# Altium schematic sheet BMS_Main.SchDoc
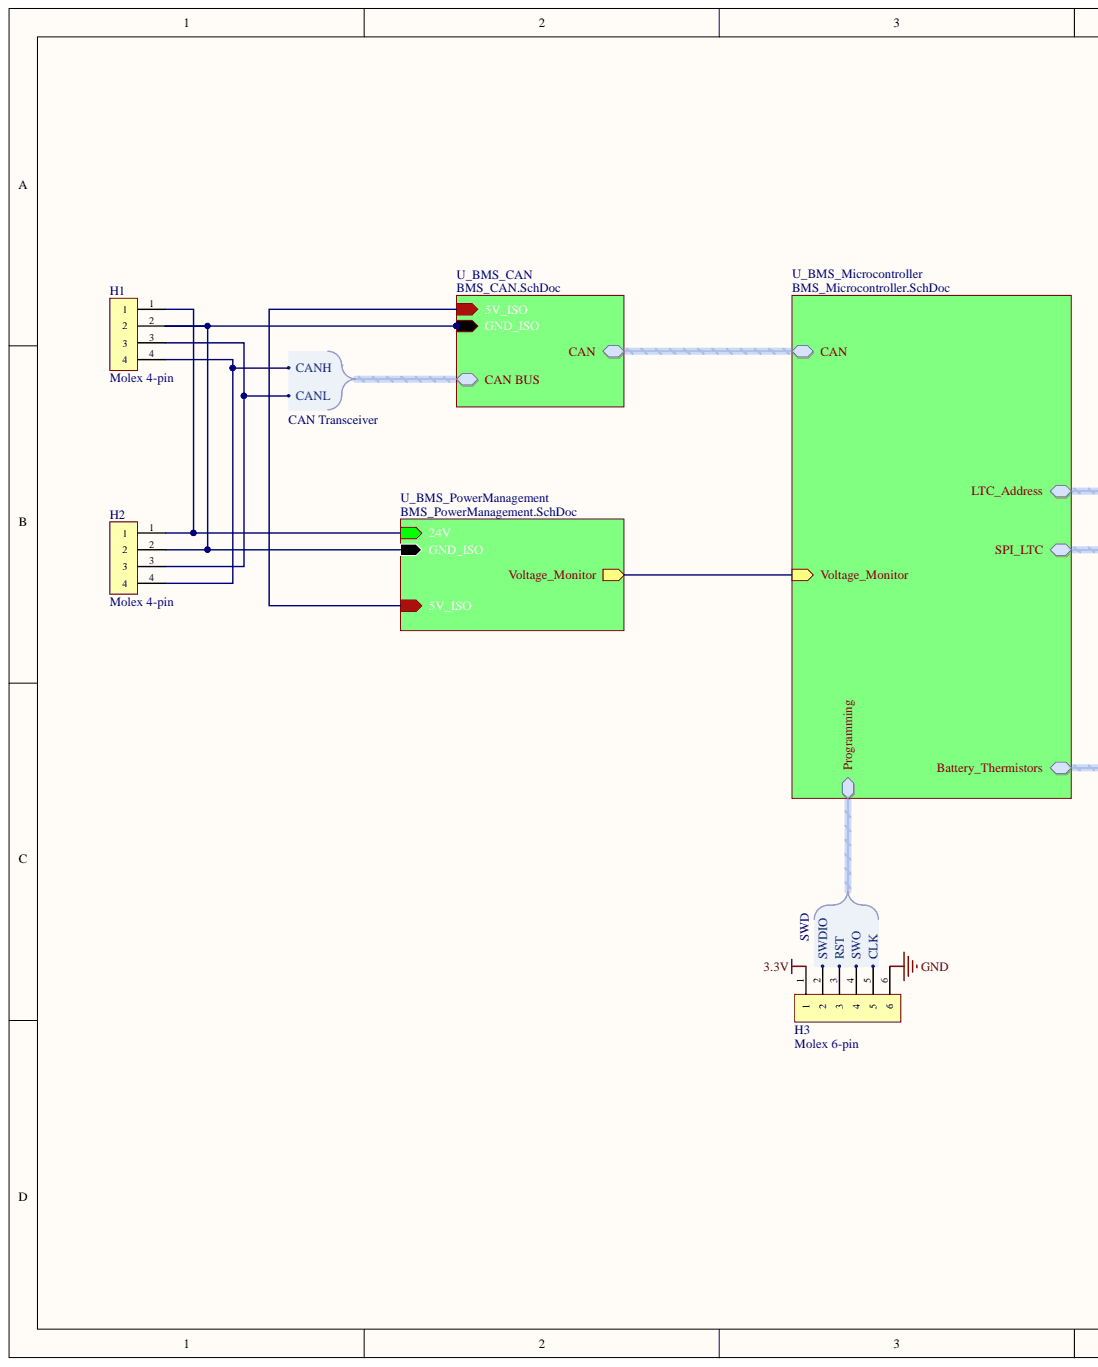
[diagram p0: BMS_Main.SchDoc, left]
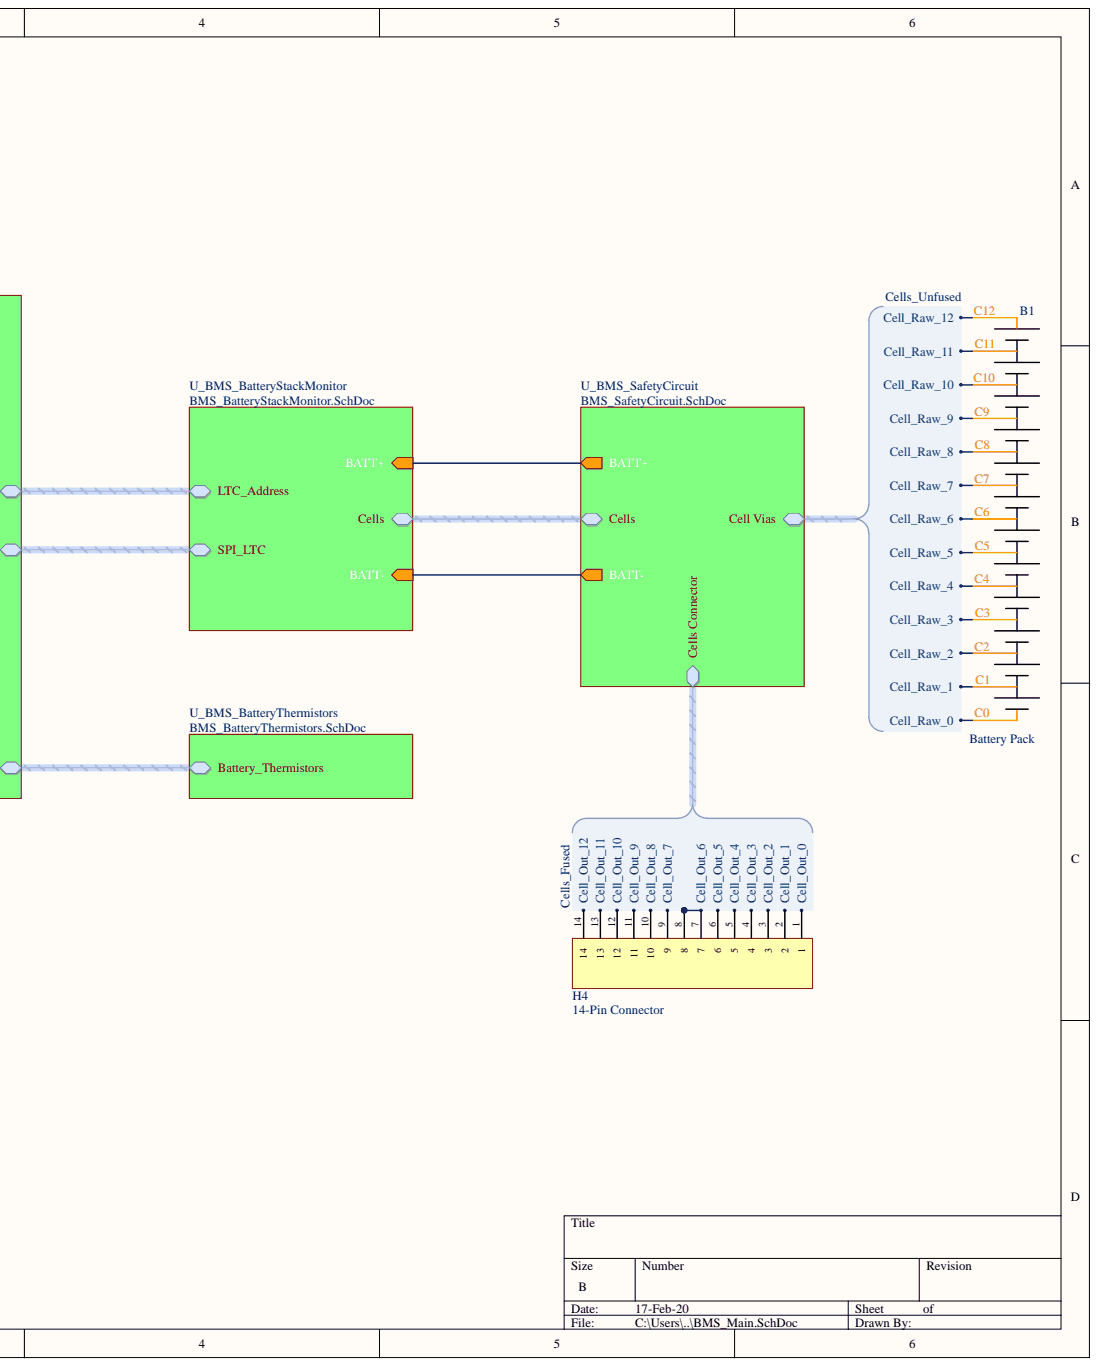
[diagram p1: BMS_Main.SchDoc, right]

## Parts
B1  Battery Pack  [Cells]
H1  Molex 4-pin  [Communication Connector]
H2  Molex 4-pin  [Communication Connector]
H3  Molex 6-pin  [ProgrammingConnector]
H4  14-Pin Connector  [CellConnector]

## Nets
3.3V: H3.1
GND: H3.6
NetB1_0: B1.0
NetB1_1: B1.1
NetB1_2: B1.2
NetB1_3: B1.3
NetB1_4: B1.4
NetB1_5: B1.5
NetB1_6: B1.6
NetB1_7: B1.7
NetB1_8: B1.8
NetB1_9: B1.9
NetB1_10: B1.10
NetB1_11: B1.11
NetB1_12: B1.12
NetH1_1: H1.1 H2.1
NetH1_2: H1.2 H2.2
NetH1_3: H1.3 H2.3
NetH1_4: H1.4 H2.4
NetH3_2: H3.2
NetH3_3: H3.3
NetH3_4: H3.4
NetH3_5: H3.5
NetH4_1: H4.1
NetH4_2: H4.2
NetH4_3: H4.3
NetH4_4: H4.4
NetH4_5: H4.5
NetH4_6: H4.6
NetH4_7: H4.7 H4.8
NetH4_9: H4.9
NetH4_10: H4.10
NetH4_11: H4.11
NetH4_12: H4.12
NetH4_13: H4.13
NetH4_14: H4.14
5V_ISO:
BATT+:
BATT-:
Battery_Thermistors.Therm1:
Battery_Thermistors.Therm2:
Battery_Thermistors.Therm3:
Battery_Thermistors.Therm4:
Battery_Thermistors.Therm5:
CAN.RXD:
CAN.TXD:
Cells.Cell_0:
Cells.Cell_1:
Cells.Cell_2:
Cells.Cell_3:
Cells.Cell_4:
Cells.Cell_5:
Cells.Cell_6:
Cells.Cell_7:
Cells.Cell_8:
Cells.Cell_9:
Cells.Cell_10:
Cells.Cell_11:
Cells.Cell_12:
LTC_Address.A0:
LTC_Address.A1:
LTC_Address.A2:
LTC_Address.A3:
SPI_LTC.CS:
SPI_LTC.MISO:
SPI_LTC.MOSI:
SPI_LTC.SCK:
Voltage_Monitor:

## Library data of the parts
B1 = Battery Pack: 12s3p battery pack, LiFePO4 GH Battery Cell with 3.7V and 8Ah; Component Kind: Standard; Library Name: BatteryPack.SchLib
H1 H2 = Molex 4-pin: Micro-Fit 3.0 Vertical Header, 3.00mm Pitch, Dual Row, 4 Circuits, with Solder Tab, Tin, Glow-Wire Capable, Black + Micro-Fit 3.0 Receptacle Housing, Dual Row, 4 Circuits, UL 94V-0, Low-Halogen, Black; Component Kind: Standard; Library Name: Molex_4-pin.SchLib
H3 = Molex 6-pin: Micro-Fit 3.0 Vertical Header, 3.00mm Pitch, Dual Row, 6 Circuits, with Solder Tab, Tin, Glow-Wire Capable, Black + Micro-Fit 3.0 Receptacle Housing, Dual Row, 6 Circuits, UL 94V-0, Low-Halogen, Black; Component Kind: Standard; Library Name: Molex 6-pin.SchLib
H4 = 14-Pin Connector: Micro-Fit 3.0 Right-Angle Header, 3.00mm Pitch, Dual Row, 14 Circuits, with Solder Tab, Tin, Glow-Wire Capable, Black + Micro-Fit 3.0 Receptacle Housing, Dual Row, 14 Circuits, UL 94V-0, Low-Halogen, Black; Component Kind: Standard; Library Name: MolexMicroFit_14-pin.SchLib
